# Altium schematic sheet pcanfd.SchDoc
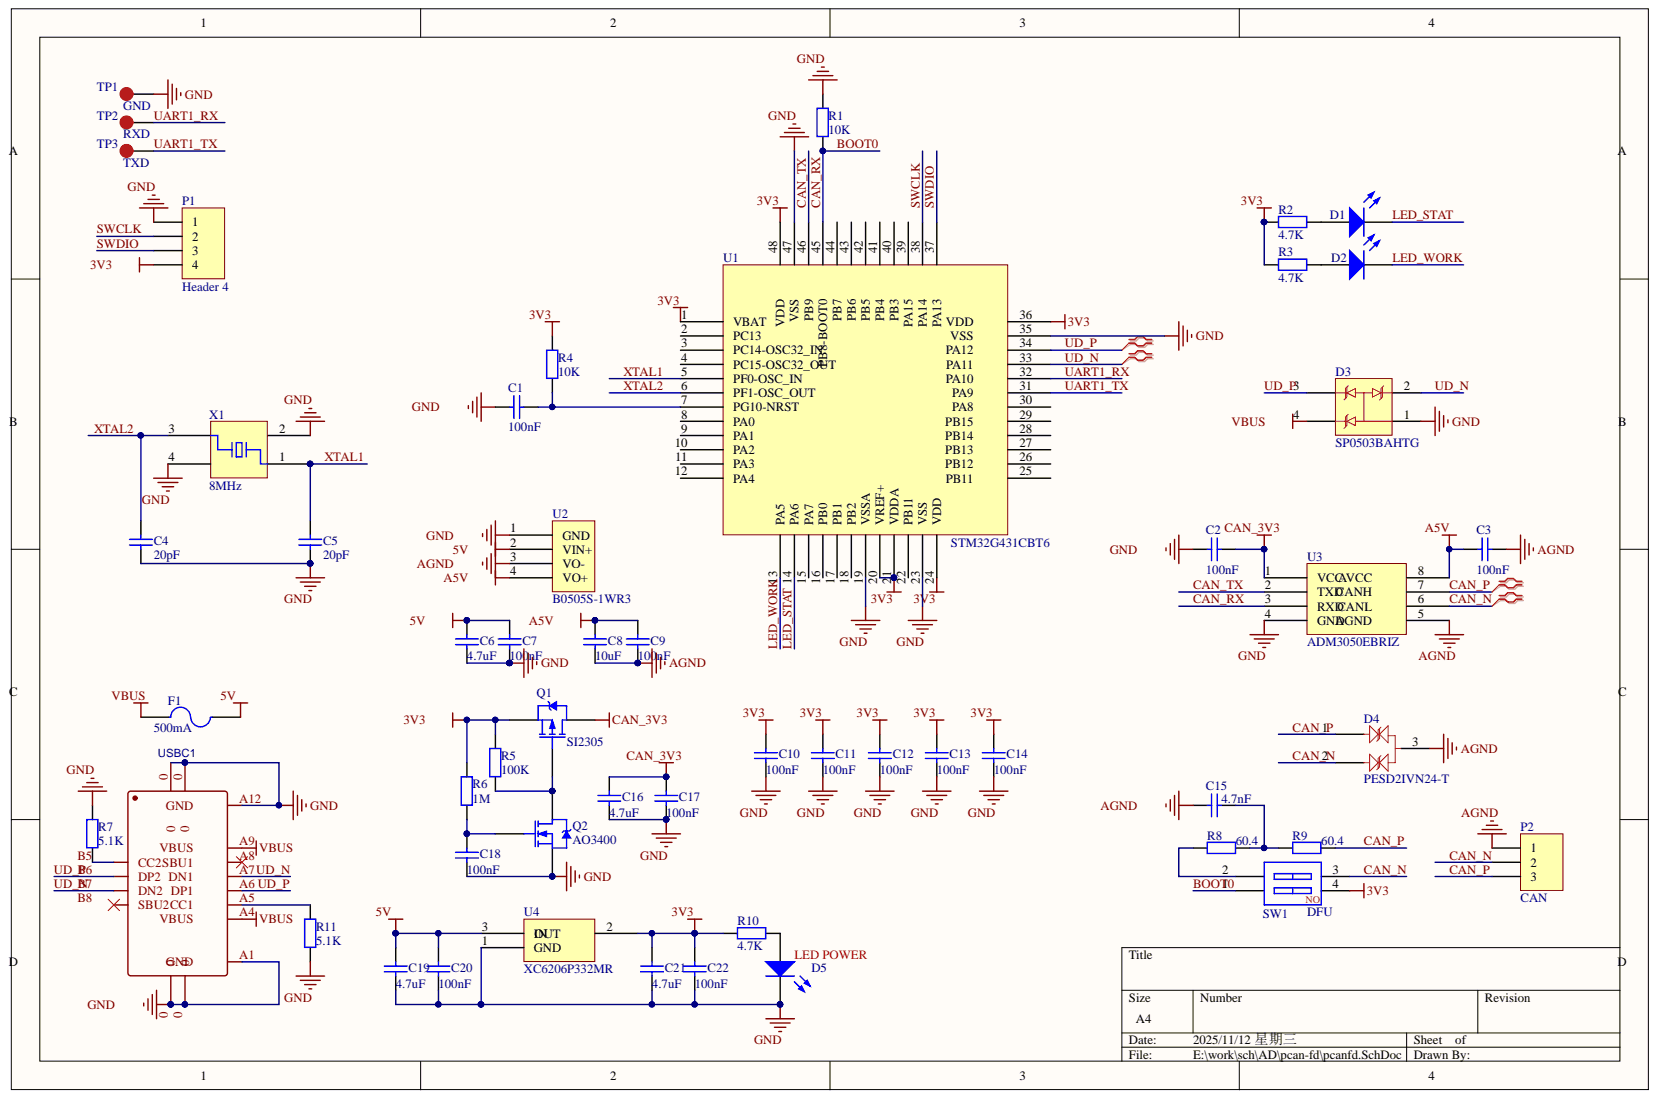
[diagram p0: pcanfd.SchDoc]

## Parts
C1  value 100nF  [1608N]  (hidden comment: Cap)
C2  value 100nF  [1608N]  (hidden comment: Cap)
C3  value 100nF  [1608N]  (hidden comment: Cap)
C4  value 20pF  [1608N]  (hidden comment: Cap)
C5  value 20pF  [1608N]  (hidden comment: Cap)
C6  value 4.7uF  [1608N]  (hidden comment: Cap)
C7  value 100nF  [1608N]  (hidden comment: Cap)
C8  value 10uF  [1608N]  (hidden comment: Cap)
C9  value 100nF  [1608N]  (hidden comment: Cap)
C10  value 100nF  [1608N]  (hidden comment: Cap)
C11  value 100nF  [1608N]  (hidden comment: Cap)
C12  value 100nF  [1608N]  (hidden comment: Cap)
C13  value 100nF  [1608N]  (hidden comment: Cap)
C14  value 100nF  [1608N]  (hidden comment: Cap)
C15  value 4.7nF  [1608N]  (hidden comment: Cap)
C16  value 4.7uF  [1608N]  (hidden comment: Cap)
C17  value 100nF  [1608N]  (hidden comment: Cap)
C18  value 100nF  [1608N]  (hidden comment: Cap)
C19  value 4.7uF  [1608N]  (hidden comment: Cap)
C20  value 100nF  [1608N]  (hidden comment: Cap)
C21  value 4.7uF  [1608N]  (hidden comment: Cap)
C22  value 100nF  [1608N]  (hidden comment: Cap)
D1  LED0  [LED 0603]  (value not drawn)
D2  LED0  [LED 0603]  (value not drawn)
D3  SP0503BAHTG  [SOT143]
D4  PESD2IVN24-T  [SOT-23]
D5  LED0  [LED 0603]  (value not drawn)
F1  500mA  [2012N]
P1  Header 4  [HDR1X4]
P2  CAN  [15EDGR-3.5-3P]
Q1  SI2305  [SOT-23]
Q2  AO3400  [SOT-23]
R1  value 10K  [1608N]  (hidden comment: Res2)
R2  value 4.7K  [1608N]  (hidden comment: Res2)
R3  value 4.7K  [1608N]  (hidden comment: Res2)
R4  value 10K  [1608N]  (hidden comment: Res2)
R5  value 100K  [1608N]  (hidden comment: Res2)
R6  value 1M  [1608N]  (hidden comment: Res2)
R7  value 5.1K  [1608N]  (hidden comment: Res2)
R8  value 60.4  [1608N]  (hidden comment: Res2)
R9  value 60.4  [1608N]  (hidden comment: Res2)
R10  value 4.7K  [1608N]  (hidden comment: Res2)
R11  value 5.1K  [1608N]  (hidden comment: Res2)
SW1  SW-2P  [CSW DIP-2P]  (value not drawn)
TP1  GND  [TP_SMT]
TP2  RXD  [TP_SMT]
TP3  TXD  [TP_SMT]
U1  STM32G431CBT6  [LQFP48_N]
U2  B0505S-1WR3  [B0505S-1WR3_HOR]
U3  ADM3050EBRIZ  [SOIC127P1150X265-8N]
U4  XC6206P332MR  [SOT-23]
USBC1  USB3.1C16PFSMT  [USB_C_12P]  (value not drawn)
X1  8MHz  [SMD3225]

## Nets
3V3: C10.1 C11.1 C12.1 C13.1 C14.1 C21.1 C22.1 P1.4 Q1.2 R2.1 R3.1 R4.1 R5.1 R6.1 R10.1 SW1.4 U1.1(VBAT) U1.20(VREF+) U1.21(VDDA) U1.24(VDD) U1.36 U1.48 U4.2
5V: C6.1 C7.1 C19.1 C20.1 F1.2 U2.2(VIN+) U4.3
A5V: C3.1 C8.1 C9.1 U2.4(VO+) U3.8
AGND: C3.2 C8.2 C9.2 C15.1 D4.3 P2.1 U2.3(VO-) U3.5
CAN_3V3: C2.1 C16.1 C17.1 Q1.3 U3.1(VCC)
GND: C1.1 C2.2 C4.2 C5.2 C6.2 C7.2 C10.2 C11.2 C12.2 C13.2 C14.2 C16.2 C17.2 C18.2 C19.2 C20.2 C21.2 C22.2 D3.1 D5.2 P1.1 Q2.2 R1.1 R7.1 R11.2 TP1.1 U1.19(VSSA) U1.23(VSS) U1.35 U1.47 U2.1(GND) U3.4(GND) U4.1 USBC1.0 USBC1.A1 USBC1.A12 X1.2 X1.4
VBUS: D3.4 F1.1 USBC1.A4 USBC1.A9
BOOT0: R1.2 SW1.1 U1.45 U3.3(RXD)
CAN_N: D4.2 P2.2 SW1.3 U3.6
CAN_P: D4.1 P2.3 R9.2 U3.7
CAN_TX: U1.46 U3.2(TXD)
LED_STAT: D1.2 U1.14(PA6)
LED_WORK: D2.2 U1.13(PA5)
SWCLK: P1.2 U1.38
SWDIO: P1.3 U1.37
UART1_RX: TP2.1 U1.32
UART1_TX: TP3.1 U1.31
UD_N: D3.2 U1.33 USBC1.A7 USBC1.B7(DN2)
UD_P: D3.3 U1.34 USBC1.A6 USBC1.B6(DP2)
XTAL1: C5.1 U1.5(PF0-OSC_IN) X1.1
XTAL2: C4.1 U1.6(PF1-OSC_OUT) X1.3
NetC1_2: C1.2 R4.2 U1.7(PG10-NRST)
NetC15_2: C15.2 R8.2 R9.1
NetC18_1: C18.1 Q2.1 R6.2
NetD1_1: D1.1 R2.2
NetD2_1: D2.1 R3.2
NetD5_1: D5.1 R10.2
NetQ1_1: Q1.1 Q2.3 R5.2
NetR7_2: R7.2 USBC1.B5
NetR8_1: R8.1 SW1.2
NetR11_1: R11.1 USBC1.A5

Not connected: U1 2(PC13) 3(PC14-OSC32_IN) 4(PC15-OSC32_OUT) 8(PA0) 9(PA1) 10(PA2) 11(PA3) 12(PA4) 15(PA7) 16(PB0) 17(PB1) 18(PB2) 22(PB11) 25 26 27 28 29 30 39 40 41 42 43 44; USBC1 A8 B8

## Library data of the parts
C1-C22 = Cap: Capacitor; Component Kind: Standard; LatestRevisionDate: 17-Jul-2002; LatestRevisionNote: Re-released for DXP Platform.; Library Name: Miscellaneous Devices.IntLib; PackageDescription: Radial Cap, Thru-Hole; 2 Leads; 0.3 in Pin Spacing; PackageReference: RAD-0.3; Published: 8-Jun-2000; Signal Integrity: Cap; Simulation: CAP; Publisher: Altium Limited
D1 D2 D5 = LED0: Typical INFRARED GaAs LED; Component Kind: Standard; LatestRevisionDate: 08-Jul-2005; LatestRevisionNote: Mech Layer 1 removed.; Library Name: Miscellaneous Devices.IntLib; PackageDescription: LED; 2 Leads; PackageReference: LED-0; Published: 8-Jun-2000; Simulation: LED0; Publisher: Altium Limited
D3 = SP0503BAHTG: 30pF 30kV Unidirectional TVS Array; Component Kind: Standard; Library Name: 我的元件库.SchLib
D4 = PESD2IVN24-T: CAN ESD; Component Kind: Standard; Library Name: 我的元件库.SchLib
F1 = Fuse 2: Fuse; Component Kind: Standard; LatestRevisionDate: 17-Jul-2002; LatestRevisionNote: Re-released for DXP Platform.; Library Name: Miscellaneous Devices.IntLib; PackageDescription: Thru-Hole; 2 Leads; PackageReference: PIN-W2/E2.8; Published: 8-Jun-2000; Simulation: FUSE; Publisher: Altium Limited
P1 = Header 4: Header, 4-Pin; Component Kind: Standard; LatestRevisionDate: 17-Jul-2002; LatestRevisionNote: Re-released for DXP Platform.; Library Name: Miscellaneous Connectors.IntLib; Signal Integrity: Connector; Publisher: Altium Limited
P2 = Header 3: Header, 3-Pin; Component Kind: Standard; LatestRevisionDate: 17-Jul-2002; LatestRevisionNote: Re-released for DXP Platform.; Library Name: Miscellaneous Connectors.IntLib; Signal Integrity: Connector; Publisher: Altium Limited
Q1 = PMOS-2: P-Channel Power MOSFET; Note: Pin 4 not drawn and electrically connected to Pin 2.; Component Kind: Standard; ComponentLink1Description: Manufacturer Link; ComponentLink1URL: http://www.irf.com/; DatasheetVersion: PD-9.390D; LatestRevisionDate: 17-Jul-2002; LatestRevisionNote: Re-released for DXP Platform.; Library Name: Miscellaneous Devices.IntLib; PackageDescription: TO, Thru-Hole, Vertical, Heatsink Mounted; 3 In-Line Leads; Pitch 2.54 mm; PackageReference: TO-220AB; PackageVersion: Feb-1997; Published: 8-Jun-2000; Simulation: IRF9510; Publisher: Altium Limited
Q2 = NMOS-2: N-Channel Power MOSFET; Note: Pin 2 is electrically connected to pin 4.; Component Kind: Standard; ComponentLink1Description: Manufacturer Link; ComponentLink1URL: http://www.irf.com/; DatasheetVersion: PD-9.814; LatestRevisionDate: 17-Jul-2002; LatestRevisionNote: Re-released for DXP Platform.; Library Name: Miscellaneous Devices.IntLib; PackageDescription: TO, Thru-Hole, Vertical, Heatsink Mounted; 3 In-Line Leads; Pitch 2.54 mm; PackageReference: TO-220AB; PackageVersion: Feb-1997; Published: 8-Jun-2000; Simulation: IRF1010; Publisher: Altium Limited
R1-R11 = Res2: Resistor; Component Kind: Standard; LatestRevisionDate: 17-Jul-2002; LatestRevisionNote: Re-released for DXP Platform.; Library Name: Miscellaneous Devices.IntLib; PackageDescription: Axial Device, Thru-Hole; 2 Leads; 0.4 in Pin Spacing; PackageReference: AXIAL-0.4; Published: 8-Jun-2000; Signal Integrity: Res; Simulation: RESISTOR; Publisher: Altium Limited
SW1 = SW-2P: 2路编码开关; BOM名称: 2路编码开关; Component Kind: Standard; Library Name: 我的元件库.SchLib
TP1-TP3 = TP: testpoint 测试点; Component Kind: Standard; Library Name: 我的元件库.SchLib
U1 = STM32G431CBT6: STM32 ARM Cortex®-M4 MCU,170MHz,128KB Flash,32KB SRAM; Component Kind: Standard; Library Name: 我的元件库.SchLib
U3 = ISO1042BDWVR: Isolated CAN transceiver; Component Kind: Standard; Library Name: 我的元件库.SchLib
U4 = XC6206P: XC6206 series; Component Kind: Standard; Library Name: 我的元件库.SchLib
X1 = XTAL-4P: 4脚无源晶振; BOM名称: 4脚无源晶振; Component Kind: Standard; Library Name: 我的元件库.SchLib
U2 = B0505S-1WR3: Component Kind: Standard; Description: B0505S-1WR3; Library Name: 我的元件库.SchLib
USBC1 = USB3.1C16PFSMT: Component Kind: Standard; Library Name: 我的元件库.SchLib
